# Altium schematic sheet Smart_RS485_1_2L.SchDoc
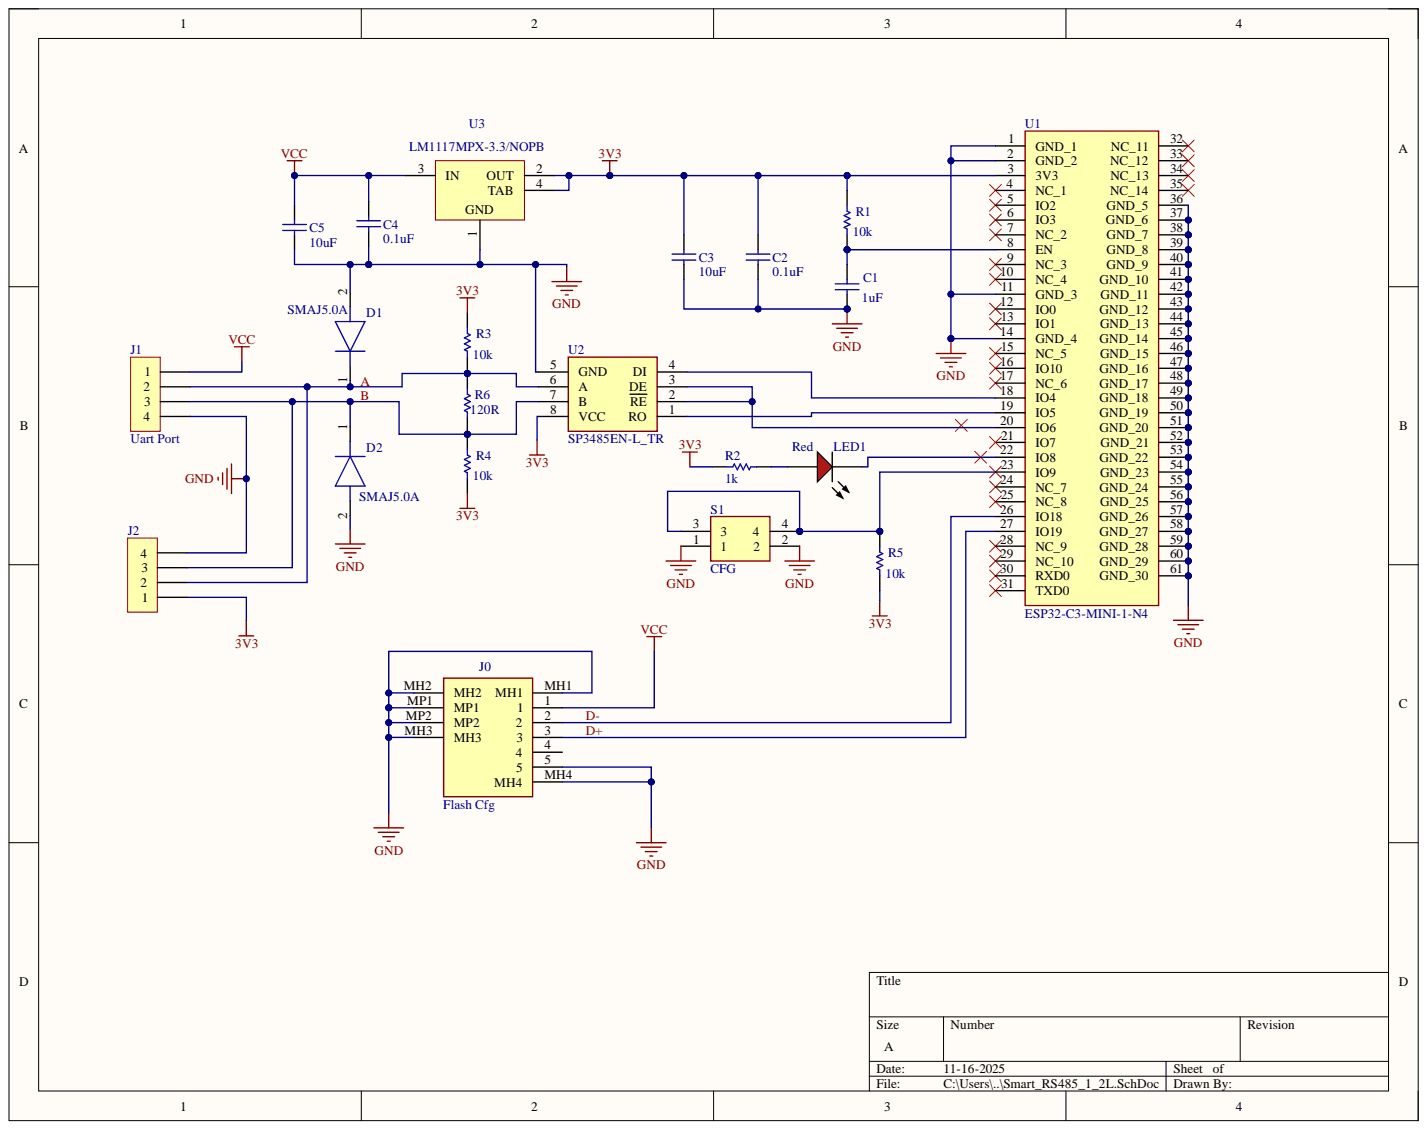
[diagram p0: Smart_RS485_1_2L.SchDoc]

## Parts
C1  1uF  [CAPC2013X135X45NL10T25]
C2  0.1uF  [CAPC2013X135X45NL10T25]
C3  10uF  [CAPC2013X135X45NL10T25]
C4  0.1uF  [CAPC2013X135X45NL10T25]
C5  10uF  [CAPC2013X135X45NL10T25]
D1  SMAJ5.0A  [DIOM5026X229N]
D2  SMAJ5.0A  [DIOM5026X229N]
J0  Flash Cfg  [101181940001LF]
J1  Uart Port  [HDR1X4]
J2  (no value)  [HDR1X4]
LED1  Red  [VISH-TLMS1000_V]
R1  10k  [RESC2013X70X40NL20T20]
R2  1k  [RESC2013X70X40NL20T20]
R3  10k  [RESC2013X70X40NL20T20]
R4  10k  [RESC2013X70X40NL20T20]
R5  10k  [RESC2013X70X40NL20T20]
R6  120R  [RESC2013X70X40NL20T20]
S1  CFG  [TL3365AF180QG]
U1  ESP32-C3-MINI-1-N4  [ESP32C3MINI1N4-Extended]
U2  SP3485EN-L_TR  [SOIC127P600X175-8N]
U3  LM1117MPX-3.3/NOPB  [DCY0004A_L]

## Nets
3V3: C2.2 C3.2 J2.1 R1.2 R2.2 R3.2 R4.1 R5.1 U1.3(3V3) U2.8(VCC) U3.2(OUT) U3.4(TAB)
GND: C1.1 C2.1 C3.1 C4.1 C5.1 D1.2 D2.2 J0.5 J0.MH1 J0.MH2 J0.MH3 J0.MH4 J0.MP1 J0.MP2 J1.4 J2.4 S1.1 S1.2 U1.1(GND_1) U1.2(GND_2) U1.11(GND_3) U1.14(GND_4) U1.36(GND_5) U1.37(GND_6) U1.38(GND_7) U1.39(GND_8) U1.40(GND_9) U1.41(GND_10) U1.42(GND_11) U1.43(GND_12) U1.44(GND_13) U1.45(GND_14) U1.46(GND_15) U1.47(GND_16) U1.48(GND_17) U1.49(GND_18) U1.50(GND_19) U1.51(GND_20) U1.52(GND_21) U1.53(GND_22) U1.54(GND_23) U1.55(GND_24) U1.56(GND_25) U1.57(GND_26) U1.58(GND_27) U1.59(GND_28) U1.60(GND_29) U1.61(GND_30) U2.5(GND) U3.1
VCC: C4.2 C5.2 J0.1 J1.1 U3.3(IN)
D+: J0.3 U1.27(IO19)
D-: J0.2 U1.26(IO18)
NetC1_2: C1.2 R1.1 U1.8(EN)
NetD1_1: D1.1 J1.2 J2.2 R3.1 R6.2 U2.6(A)
NetD2_1: D2.1 J1.3 J2.3 R4.2 R6.1 U2.7(B)
NetLED1_1: LED1.1 R2.1
NetLED1_2: LED1.2 U1.22(IO8)
NetR5_2: R5.2 S1.3 S1.4 U1.23(IO9)
NetU1_18: U1.18(IO4) U2.4(DI)
NetU1_19: U1.19(IO5) U2.1(RO)
NetU1_20: U1.20(IO6) U2.2(RE) U2.3(DE)
A:
B:

Not connected: J0 4; U1 4(NC_1) 5(IO2) 6(IO3) 7(NC_2) 9(NC_3) 10(NC_4) 12(IO0) 13(IO1) 15(NC_5) 16(IO10) 17(NC_6) 21(IO7) 24(NC_7) 25(NC_8) 28(NC_9) 29(NC_10) 30(RXD0) 31(TXD0) 32(NC_11) 33(NC_12) 34(NC_13) 35(NC_14)

## Library data of the parts
C1-C5 = CMP-2007-03607-1: Manufacturer: Murata; Part Number: GRM21BR72E103KW03L; Component Kind: Standard; Library Name: Altium Content Vault
  C1: value: 1uf
  C2 C4: value: 0.1uf
  C3 C5: value: 10uf
D1 D2 = SMAJ5.0A: -55℃~+150℃ 400W@10/1000us 43.5A@10/1000us 5V 7.25V 800uA 9.2V IEC 61000-4-2 TVS Unidirectional DO-214AC(SMA) ESD and Surge Protection (TVS/ESD) ROHS; Manufacturer: RUILON(Shenzhen Ruilongyuan Elec); Arrow Part Number: SMAJ5.0A; Arrow Price/Stock: https://www.arrow.com/en/products/smaj5.0a/bourns?utm_currency=USD&region=nac; Component Kind: Standard; Datasheet Link: https://detail.tmall.com/item.htm?id=548354020241&ali_refid=a3_430583_1006:1109983619:N:3Uw9kZ3UMDT/QL57nh1fXA==:ab063b49c94624768a940a913313feb0&ali_trackid=1_ab063b49c94624768a940a913313feb0&spm=a230r.1.14.1; Height: 2.29mm; JLCPCB Part Number: C10758; Mouser Price/Stock: https://www.mouser.co.uk/ProductDetail/Bourns/SMAJ5.0A?qs=A3H9pljuJ8RbLIvzFxDfUA%3D%3D; Package: DO-214AC(SMA); Part Number: SMAJ5.0A
J0 = 10118194-0001LF: Connector; Manufacturer: Amphenol Communications Solutions; Arrow Part Number: 10118194-0001LF; Arrow Price/Stock: https://www.arrow.com/en/products/10118194-0001lf/amphenol-fci?utm_currency=USD&region=nac; Component Kind: Standard; Datasheet Link: https://www.amphenol-cs.com/micro-usb-101181940001lf.html; Height: 3.25mm; Part Number: 10118194-0001LF
J1 J2 = Header 4: Header, 4-Pin; Component Kind: Standard; LatestRevisionDate: 17-Jul-2002; LatestRevisionNote: Re-released for DXP Platform.; Signal Integrity: Connector; Library Name: Miscellaneous Connectors HZ.IntLib; Publisher: Altium Limited
LED1 = CMP-2000-07254-1: Low Current LED, 40 mW, 1.8 V, -40 to 100 degC, 2-Pin SMD (0603), RoHS, Tape and Reel; Manufacturer: Vishay; Part Number: TLMS1000-GS08; Automotive Qualification Standard: AEC-Q100; Bulb Size: 1.6mmx0.8mm; Forward Current If: 2mA; Forward Voltage: 1.8V; Lens Shape: Rectangular; Luminous Intensity: 4mcd; SVHC: ToBeAdvised; Viewing Angle: 80°; Wavelength Typ: 636nm; Component Kind: Standard; Datasheet URL: https://datasheet.ciiva.com/5798/1698881-5798337.pdf; Datasheet Version: Rev. 1.7, 02/2011; LED Colour: Red; LED Mounting: SMD; Library Name: Altium Content Vault; Manufacturer URL: http://www.vishay.com/; Mounting Technology: SurfaceMount; MSL: MSL2-1year; Package Description: 2-Pin Surface Mount Device, Body 1.6 x 0.8 mm; Package Reference: TLMS1000; Packaging: CutTape; Product Range: -; Value: Red
R1 R3-R6 = CMP-1665-00002-5: PartNumber: ERJ-6GEY0R00V; Resistance: 0 Ohm; Tolerance: 5%; Case Code (Imperial): 0805; Case Code (Metric): 2012; Case/Package: 0805; Component Kind: Standard; ComponentLink1Description: Manufacturer URL; ComponentLink1URL: http://www.panasonic.com/; ComponentLink2Description: Datasheet; ComponentLink2URL: http://industrial.panasonic.com/cdbs/www-data/pdf/RDA0000/AOA0000C84.pdf; DatasheetVersion: 03/2015; Height: 0.6 mm; Length: 2 mm; Library Name: Altium Content Vault; Max Operating Temperature: 155 degC; Min Operating Temperature: -55 degC; Mounting Technology: Surface Mount; Package Quantity: 5000; PackageDescription: 2-Pin Surface Mount Device, Body 2 x 1.25 mm; Packaging: Tape and Reel; Radiation Hardening: No; REACH SVHC: No SVHC; RoHSCompliant: True; Width: 1.25 mm
  R1: Parameter 1value: 10k
  R4 R5: value: 10k
R2 = CMP-1665-00002-5: PartNumber: ERJ-6GEY0R00V; Parameter 1value: 10k; Resistance: 0 Ohm; Tolerance: 5%; Case Code (Imperial): 0805; Case Code (Metric): 2012; Case/Package: 0805; Component Kind: Standard; ComponentLink1Description: Manufacturer URL; ComponentLink1URL: http://www.panasonic.com/; ComponentLink2Description: Datasheet; ComponentLink2URL: http://industrial.panasonic.com/cdbs/www-data/pdf/RDA0000/AOA0000C84.pdf; DatasheetVersion: 03/2015; Height: 0.6 mm; Length: 2 mm; Library Name: Altium Content Vault; Max Operating Temperature: 155 degC; Min Operating Temperature: -55 degC; Mounting Technology: Surface Mount; Package Quantity: 5000; PackageDescription: 2-Pin Surface Mount Device, Body 2 x 1.25 mm; Packaging: Tape and Reel; Radiation Hardening: No; REACH SVHC: No SVHC; RoHSCompliant: True; Width: 1.25 mm
S1 = TL3365AF180QG: Switch; Manufacturer: E-Switch; Component Kind: Standard; Datasheet Link: https://configured-product-images.s3.amazonaws.com/2D/specs/TL3365AF180QG.pdf; Height: 2.75mm; Mouser Part Number: 612-TL3365AF180QG; Mouser Price/Stock: https://www.mouser.co.uk/ProductDetail/E-Switch/TL3365AF180QG?qs=xQzBpF%2FAFTo%252BA4IL7l2Rvw%3D%3D; Part Number: TL3365AF180QG
U1 = ESP32-C3-MINI-1-N4: Integrated Circuit; Manufacturer: Espressif Systems; Component Kind: Standard; Datasheet Link: https://www.mouser.com/datasheet/2/891/Espressif_ESP32_C3_MINI_1_Datasheet-2006822.pdf; Height: 2.55mm; Library Name: SamacSys.SchLib; Mouser Part Number: 356-ESP32-C3-MINI1N4; Mouser Price/Stock: https://www.mouser.co.uk/ProductDetail/Espressif-Systems/ESP32-C3-MINI-1-N4?qs=stqOd1AaK7%252B%2FpH3qqyGehA%3D%3D; Part Number: ESP32-C3-MINI-1-N4
U2 = SP3485EN-L_TR: -40℃~+85℃ 1 1 10Mbps 2mA 3.3V 32 Half-Duplex Transceiver SOIC-8 RS-485 / RS-422 ICs ROHS; Manufacturer: MaxLinear; Part Number: SP3485EN-L/TR; Arrow Part Number: SP3485EN-L/TR; Arrow Price/Stock: https://www.arrow.com/en/products/sp3485en-ltr/exar; Component Kind: Standard; Datasheet Link: https://componentsearchengine.com/Datasheets/1/SP3485EN-L_TR.pdf; Height: 1.75mm; JLCPCB Part Number: C8963; Mouser Price/Stock: https://www.mouser.com/Search/Refine.aspx?Keyword=701-SP3485EN-L%2FTR
U3 = CMP-0062-01639-4: 800mA Low-Dropout Linear Regulator, 4-pin SOT-223, Pb-Free; Manufacturer: Texas Instruments; CompType: LDO IC; High-Reliability: Y; PackageTopMarking: XYTT*N05A; PowerWise: N; Code_IPC: SOT223-4; Code_JEDEC: TO-261-AA; Component Kind: Standard; ComponentLink1Description: Datasheet URL; ComponentLink1URL: http://www.ti.com/general/docs/lit/getliterature.tsp?genericPartNumber=LM1117-N&fileType=pdf; Library Name: Altium Content Vault; PackageDescription: Molded SOT-223, 6.50 x 3.56 x 1.6mm Body, 4 Lead, 2.3mm Pitch; PackageReference: DCY0004A; Part Number: LM1117MPX-3.3/NOPB; PinCount: 4; RoHS: Y
